# Altium schematic sheet Sheet5.SchDoc
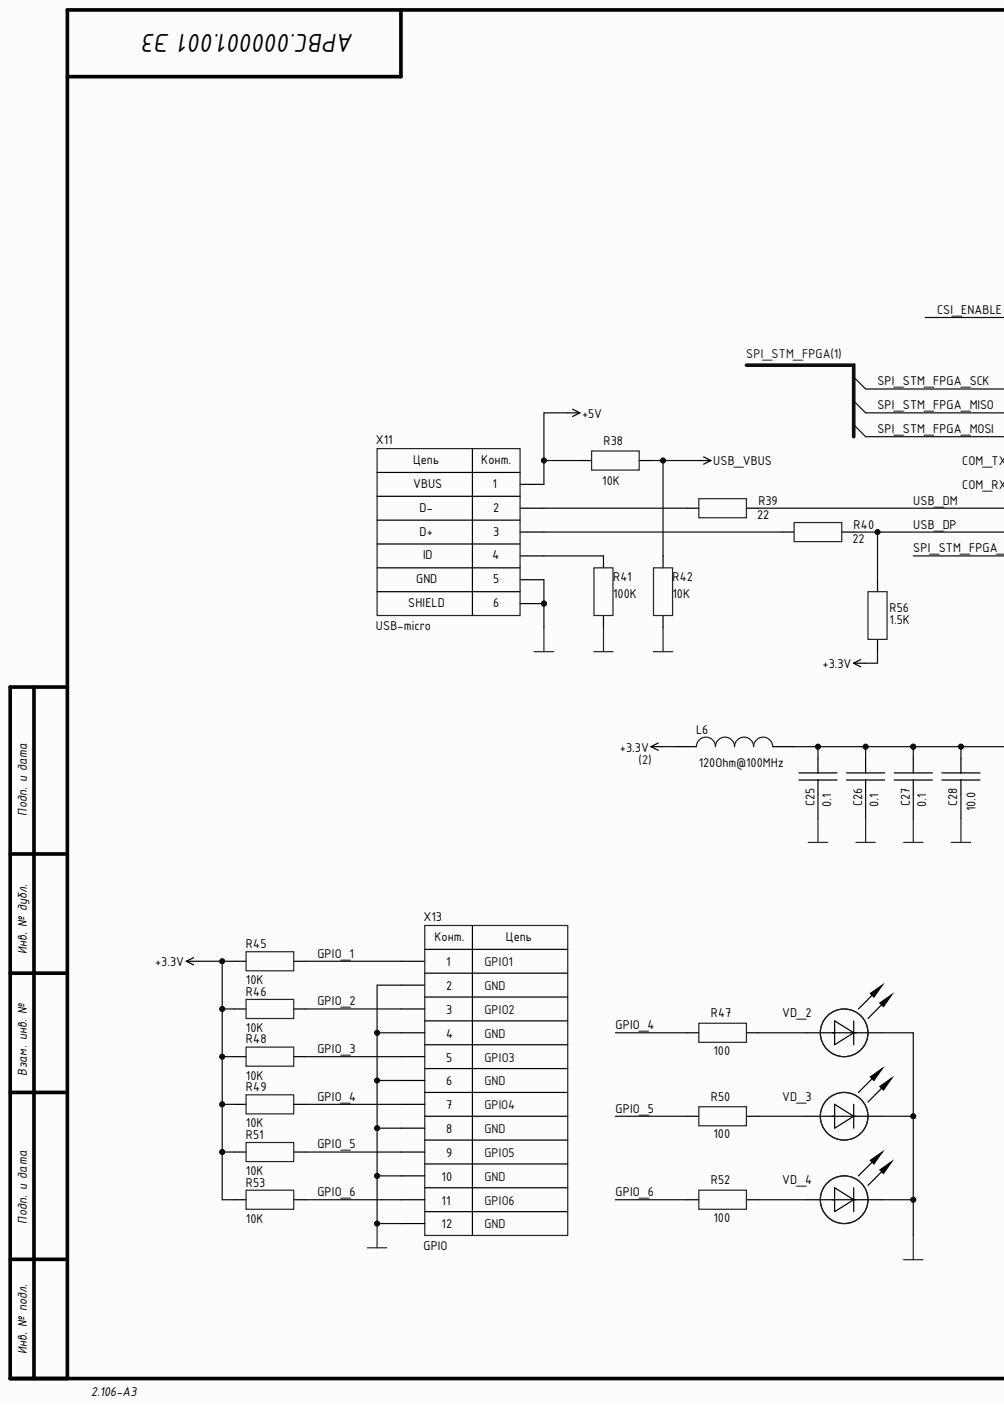
[diagram p0: Sheet5.SchDoc, left]
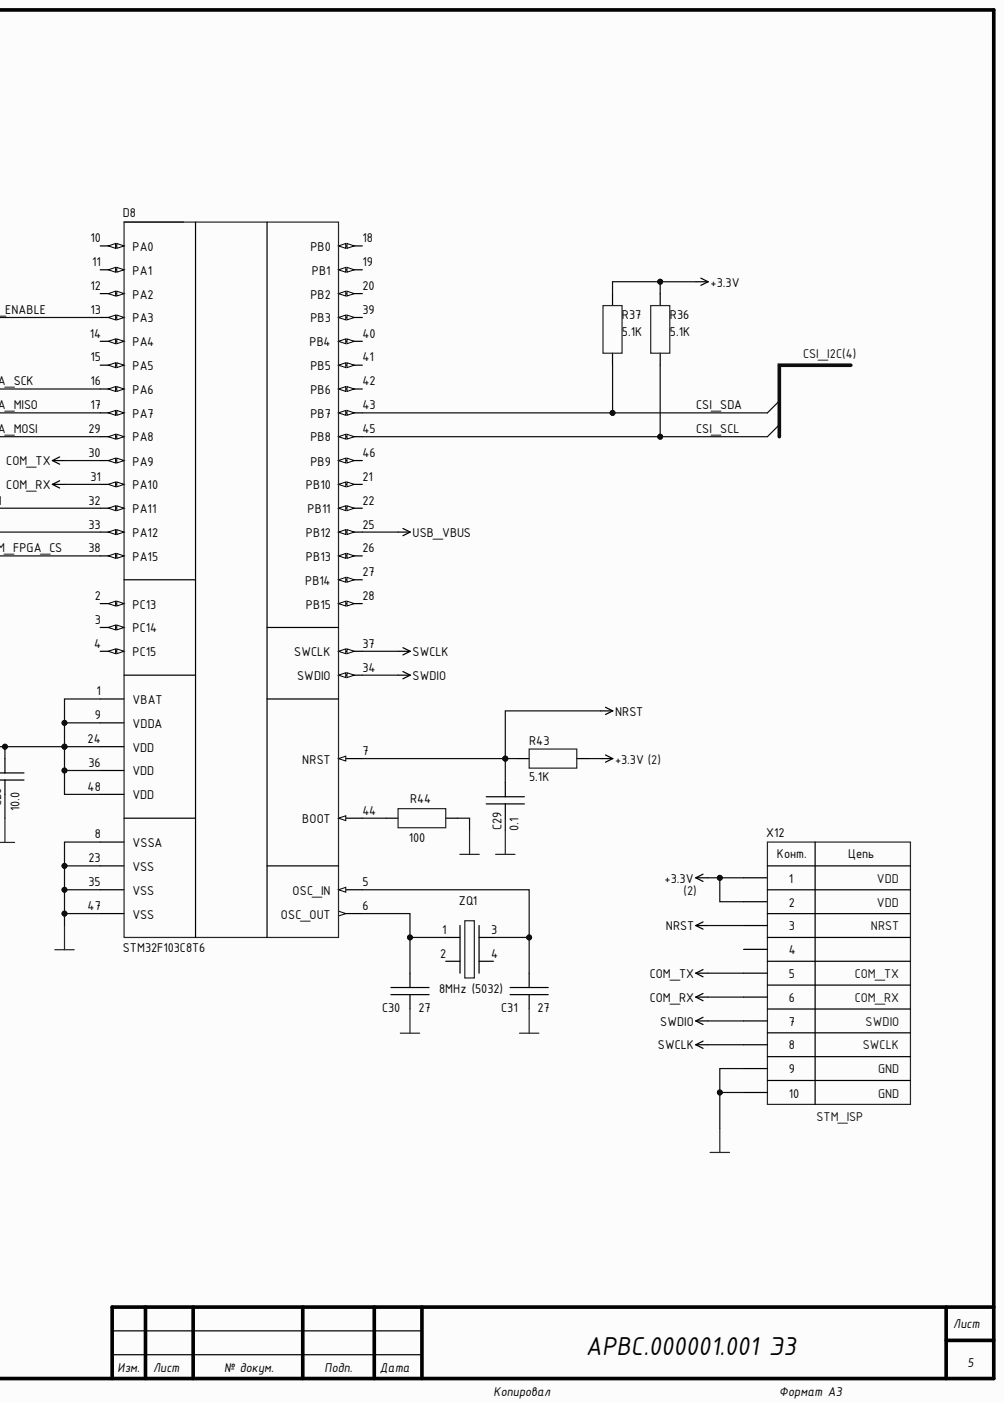
[diagram p1: Sheet5.SchDoc, right]

## Parts
C25  value 0.1  [CAP: 0603]  (hidden comment: Ceramic capacitor, 0.1, X7R, ±10%, 6.3V, 0603, -55...+125°C)
C26  value 0.1  [CAP: 0603]  (hidden comment: Ceramic capacitor, 0.1, X7R, ±10%, 6.3V, 0603, -55...+125°C)
C27  value 0.1  [CAP: 0603]  (hidden comment: Ceramic capacitor, 0.1, X7R, ±10%, 6.3V, 0603, -55...+125°C)
C28  value 10.0  [CAP: 0805]  (hidden comment: Ceramic capacitor, 10.0, X5R, ±10%, 6.3V, 0805, -55...+85°C)
C29  value 0.1  [CAP: 0603]  (hidden comment: Ceramic capacitor, 0.1, X7R, ±10%, 6.3V, 0603, -55...+125°C)
C30  value 27  [CAP: 0805]  (hidden comment: Ceramic capacitor, 27, X7R, ±10%, 16V, 0805, -55...+125°C)
C31  value 27  [CAP: 0805]  (hidden comment: Ceramic capacitor, 27, X7R, ±10%, 16V, 0805, -55...+125°C)
D8  IC microcontroller, 32-Bit, Cortex-M3, 72MHz, 64KB, 20KB, 2...3.6V, -40...+85°C, 48-LQFP  [48-LQFP]  (value not drawn)
L6  value 120Ohm@100MHz  [IND: 0603]  (hidden comment: Fixed inductor, 120Ohm@100MHz, ±20%, 0603, 300mA, -55...+125°C)
R36  value 5.1K  [RES: 0603]  (hidden comment: Resistor film, 5.1K, ±5%, 0603, 0.1W, -55...+125°C)
R37  value 5.1K  [RES: 0603]  (hidden comment: Resistor film, 5.1K, ±5%, 0603, 0.1W, -55...+125°C)
R38  value 10K  [RES: 0603]  (hidden comment: Resistor film, 10K, ±5%, 0603, 0.1W, -55...+125°C)
R39  value 22  [RES: 0603]  (hidden comment: Resistor film, 22, ±5%, 0603, 0.1W, -55...+125°C)
R40  value 22  [RES: 0603]  (hidden comment: Resistor film, 22, ±5%, 0603, 0.1W, -55...+125°C)
R41  value 100K  [RES: 0603]  (hidden comment: Resistor film, 100K, ±5%, 0603, 0.1W, -55...+125°C)
R42  value 10K  [RES: 0603]  (hidden comment: Resistor film, 10K, ±5%, 0603, 0.1W, -55...+125°C)
R43  value 5.1K  [RES: 0603]  (hidden comment: Resistor film, 5.1K, ±5%, 0603, 0.1W, -55...+125°C)
R44  value 100  [RES: 0603]  (hidden comment: Resistor film, 100, ±5%, 0603, 0.1W, -55...+125°C)
R45  value 10K  [RES: 0603]  (hidden comment: Resistor film, 10K, ±1%, 0603, 0.1W, -55...+125°C)
R46  value 10K  [RES: 0603]  (hidden comment: Resistor film, 10K, ±1%, 0603, 0.1W, -55...+125°C)
R47  value 100  [RES: 0603]  (hidden comment: Resistor film, 100, ±1%, 0603, 0.1W, -55...+125°C)
R48  value 10K  [RES: 0603]  (hidden comment: Resistor film, 10K, ±1%, 0603, 0.1W, -55...+125°C)
R49  value 10K  [RES: 0603]  (hidden comment: Resistor film, 10K, ±1%, 0603, 0.1W, -55...+125°C)
R50  value 100  [RES: 0603]  (hidden comment: Resistor film, 100, ±1%, 0603, 0.1W, -55...+125°C)
R51  value 10K  [RES: 0603]  (hidden comment: Resistor film, 10K, ±1%, 0603, 0.1W, -55...+125°C)
R52  value 100  [RES: 0603]  (hidden comment: Resistor film, 100, ±1%, 0603, 0.1W, -55...+125°C)
R53  value 10K  [RES: 0603]  (hidden comment: Resistor film, 10K, ±1%, 0603, 0.1W, -55...+125°C)
R56  value 1.5K  [RES: 0603]  (hidden comment: Resistor film, 1.5K, ±5%, 0603, 0.1W, -55...+125°C)
VD_2  LED, Green, 20mA, 2.9, 0603, -55...+150°C  [LED: 0603G]  (value not drawn)
VD_3  LED, Green, 20mA, 2.9, 0603, -55...+150°C  [LED: 0603G]  (value not drawn)
VD_4  LED, Green, 20mA, 2.9, 0603, -55...+150°C  [LED: 0603G]  (value not drawn)
X11  CommentText: USB-micro  [CON: USB micro-A (ZX62-B-5PA)]  (hidden comment: USB micro B)
X12  Connector, 10pin, 2.54mm, 250VAC, 3A  [CON: IDC-10MS]  (value not drawn)
X13  CommentText: GPIO  [CON: PLD-12]  (hidden comment: Connector, 12pin, 2.54mm, 500VAC, 3A)
ZQ1  Frequency: 8MHz (5032)  [OSC: 5032]  (hidden comment: Crystal, 8MHz (5032), 20pF, ±30ppm, case 5032, -40...+85°C)

## Nets
+3.3V: L6.2 R36.2 R37.2 R43.1 R45.2 R46.2 R48.2 R49.2 R51.2 R53.2 R56.2 X12.1 X12.2
+5V: R38.1 X11.1
COM_RX: D8.31(PA10) X12.6
COM_TX: D8.30(PA9) X12.5
GND: C25.1 C26.1 C27.1 C28.1 C29.1 C30.1 C31.1 D8.8(VSSA) D8.23(VSS) D8.35(VSS) D8.47(VSS) R41.1 R42.1 R44.2 VD_2.2 VD_3.2 VD_4.2 X11.0 X11.5 X12.9 X12.10 X13.2 X13.4 X13.6 X13.8 X13.10 X13.12
NRST: C29.2 D8.7(NRST) R43.2 X12.3
SWCLK: D8.37(SWCLK) X12.8
SWDIO: D8.34(SWDIO) X12.7
USB_VBUS: D8.25(PB12) R38.2 R42.2
CSI_ENABLE: D8.13(PA3)
CSI_SCL: D8.45(PB8) R36.1
CSI_SDA: D8.43(PB7) R37.1
GPIO_1: R45.1 X13.1
GPIO_2: R46.1 X13.3
GPIO_3: R48.1 X13.5
GPIO_4: R47.1 R49.1 X13.7
GPIO_5: R50.1 R51.1 X13.9
GPIO_6: R52.1 R53.1 X13.11
SPI_STM_FPGA_CS: D8.38(PA15)
SPI_STM_FPGA_MISO: D8.17(PA7)
SPI_STM_FPGA_MOSI: D8.29(PA8)
SPI_STM_FPGA_SCK: D8.16(PA6)
USB_DM: D8.32(PA11) R39.2
USB_DP: D8.33(PA12) R40.2 R56.1
NetC25_2: C25.2 C26.2 C27.2 C28.2 D8.1(VBAT) D8.9(VDDA) D8.24(VDD) D8.36(VDD) D8.48(VDD) L6.1
NetC30_2: C30.2 D8.6(OSC_OUT) ZQ1.1
NetC31_2: C31.2 D8.5(OSC_IN) ZQ1.3
NetD8_44: D8.44(BOOT) R44.1
NetR39_1: R39.1 X11.2
NetR40_1: R40.1 X11.3
NetR41_2: R41.2 X11.4
NetR47_2: R47.2 VD_2.1
NetR50_2: R50.2 VD_3.1
NetR52_2: R52.2 VD_4.1

Not connected: D8 2(PC13) 3(PC14) 4(PC15) 10(PA0) 11(PA1) 12(PA2) 14(PA4) 15(PA5) 18(PB0) 19(PB1) 20(PB2) 21(PB10) 22(PB11) 26(PB13) 27(PB14) 28(PB15) 39(PB3) 40(PB4) 41(PB5) 42(PB6) 46(PB9); X12 4; ZQ1 2 4

## Library data of the parts
C25-C27 C29 = Ceramic_0603: Manufacturer: Yageo; PartNumber: CC0603KRX7R7BB104; Component Kind: Standard; HelpURL: https://www.digikey.com/product-detail/en/yageo/CC0603KRX7R7BB104/311-1088-1-ND/302998; Library Name: Capacitor.IntLib; Package: 0603; Temperature: -55...+125°C; Tolerance: ±10%; TypeCapacitor: Ceramic capacitor; TypeDielectric: X7R; ValueManufacturerPartNumber: Ceramic capacitor, 0.1, X7R, ±10%, 6.3V, 0603, -55...+125°C; Voltage: 6.3V
C28 = Ceramic_0805: Manufacturer: Murata; PartNumber: GRM21BR60J106K; Component Kind: Standard; HelpURL: https://www.chipdip.ru/product/grm21br60j106k; Library Name: Capacitor.IntLib; Package: 0805; Temperature: -55...+85°C; Tolerance: ±10%; TypeCapacitor: Ceramic capacitor; TypeDielectric: X5R; ValueManufacturerPartNumber: Ceramic capacitor, 10.0, X5R, ±10%, 6.3V, 0805, -55...+85°C; Voltage: 6.3V
C30 C31 = Ceramic_0805: Manufacturer: Yageo; PartNumber: CC0805KRX7R7BB104; Component Kind: Standard; HelpURL: https://www.digikey.com/product-detail/en/yageo/CC0805KRX7R7BB104/311-1142-1-ND/303052; Library Name: Capacitor.IntLib; Package: 0805; Temperature: -55...+125°C; Tolerance: ±10%; TypeCapacitor: Ceramic capacitor; TypeDielectric: X7R; ValueManufacturerPartNumber: Ceramic capacitor, 27, X7R, ±10%, 16V, 0805, -55...+125°C; Voltage: 16V
D8 = STM32F103C8T6: Cortex-M3; Manufacturer: STMicroelectronics; Component Kind: Standard; ComponentType: IC microcontroller; CoreProcessor: Cortex-M3; CoreSize: 32-Bit; HelpURL: https://www.digikey.com/product-detail/en/stmicroelectronics/STM32F103C8T6TR/497-17666-1-ND/7804402; Library Name: IC. MCU.IntLib; MemoryRAM: 20KB; MemoryROM: 64KB; Package: 48-LQFP; PartNumber: STM32F103C8T6; Speed: 72MHz; Supply: 2...3.6V; Temperature: -40...+85°C; ValueManufacturerPartNumber: IC microcontroller, 32-Bit, Cortex-M3, 72MHz, 64KB, 20KB, 2...3.6V, -40...+85°C, 48-LQFP
L6 = Inductor_0603: Manufacturer: Murata Electronics; PartNumber: GZ1608; Component Kind: Standard; HelpURL: https://www.compel.ru/infosheet/SUNLORD/GZ1608D121TF; Library Name: Inductor.IntLib; MaxCurrent: 300mA; Package: 0603; Temperature: -55...+125°C; Tolerance: ±20%; TypeInductor: Fixed inductor; ValueManufacturerPartNumber: Fixed inductor, 120Ohm@100MHz, ±20%, 0603, 300mA, -55...+125°C
R36-R53 R56 = Resistor_0603: Manufacturer: Yageo; PartNumber: RC0603JR-07100RL; Component Kind: Standard; HelpURL: https://www.digikey.com/product-detail/en/yageo/RC0603JR-07100RL/311-100GRCT-ND/729644; Library Name: Resistor.IntLib; Package: 0603; Power: 0.1W; Temperature: -55...+125°C; TypeResistor: Resistor film
  R36 R37 R43: Tolerance: ±5%; ValueManufacturerPartNumber: Resistor film, 5.1K, ±5%, 0603, 0.1W, -55...+125°C
  R38 R42: Tolerance: ±5%; ValueManufacturerPartNumber: Resistor film, 10K, ±5%, 0603, 0.1W, -55...+125°C
  R39 R40: Tolerance: ±5%; ValueManufacturerPartNumber: Resistor film, 22, ±5%, 0603, 0.1W, -55...+125°C
  R41: Tolerance: ±5%; ValueManufacturerPartNumber: Resistor film, 100K, ±5%, 0603, 0.1W, -55...+125°C
  R44: Tolerance: ±5%; ValueManufacturerPartNumber: Resistor film, 100, ±5%, 0603, 0.1W, -55...+125°C
  R45 R46 R48 R49 R51 R53: Tolerance: ±1%; ValueManufacturerPartNumber: Resistor film, 10K, ±1%, 0603, 0.1W, -55...+125°C
  R47 R50 R52: Tolerance: ±1%; ValueManufacturerPartNumber: Resistor film, 100, ±1%, 0603, 0.1W, -55...+125°C
  R56: Tolerance: ±5%; ValueManufacturerPartNumber: Resistor film, 1.5K, ±5%, 0603, 0.1W, -55...+125°C
VD_2-VD_4 = LED_single: PartNumber: TO-1608BC-PG; VoltageDrop: 2.9; Color: GREEN; ColorParameter: Green; Component Kind: Standard; Current: 20mA; HelpURL: https://www.chipdip.ru/product/to-1608bc-pg; Library Name: Diode.IntLib; Package: 0603; Temperature: -55...+150°C; TypeDiode: LED; ValueManufacturerPartNumber: LED, Green, 20mA, 2.9, 0603, -55...+150°C
X11 = Connector_USB_micro_B: Manufacturer: Hirose Electric; PartNumber: ZX62-B-5PA; Component Kind: Standard; HelpURL: https://www.digikey.com/product-detail/en/hirose-electric-co-ltd/ZX62-B-5PA/H11579CT-ND/1851090; Library Name: Connector.IntLib; TypeConnector: USB micro B; ValueManufacturerPartNumber: USB micro B
X12 = IDC-10: Manufacturer: Connfly; Component Kind: Standard; CurrentMax: 3A; HelpURL: https://www.chipdip.ru/product/idc-10ms; Library Name: Connector.IntLib; Multichannel: 10pin; PartNumber: IDC-10; Pitch: 2.54mm; TypeConnector: Connector; ValueManufacturerPartNumber: Connector, 10pin, 2.54mm, 250VAC, 3A; VoltageMax: 250VAC
X13 = PLD-12: Manufacturer: Connfly; Component Kind: Standard; CurrentMax: 3A; HelpURL: https://www.chipdip.ru/product/pld-12; Library Name: Connector.IntLib; Multichannel: 12pin; PartNumber: PLD-12; Pitch: 2.54mm; TypeConnector: Connector; ValueManufacturerPartNumber: Connector, 12pin, 2.54mm, 500VAC, 3A; VoltageMax: 500VAC
ZQ1 = Crystal_5032: Manufacturer: Diodes Incorporated; PartNumber: FY1000009; Component Kind: Standard; HelpURL: https://www.digikey.com/product-detail/en/diodes-incorporated/FY1000009/FY1000009CT-ND/6043911; Library Name: Oscilator.IntLib; LoadCapacitance: 20pF; Package: case 5032; Temperature: -40...+85°C; Tolerance: ±30ppm; TypeOscilator: Crystal; ValueManufacturerPartNumber: Crystal, 8MHz (5032), 20pF, ±30ppm, case 5032, -40...+85°C
